# Altium schematic sheet Sheet1.SchDoc
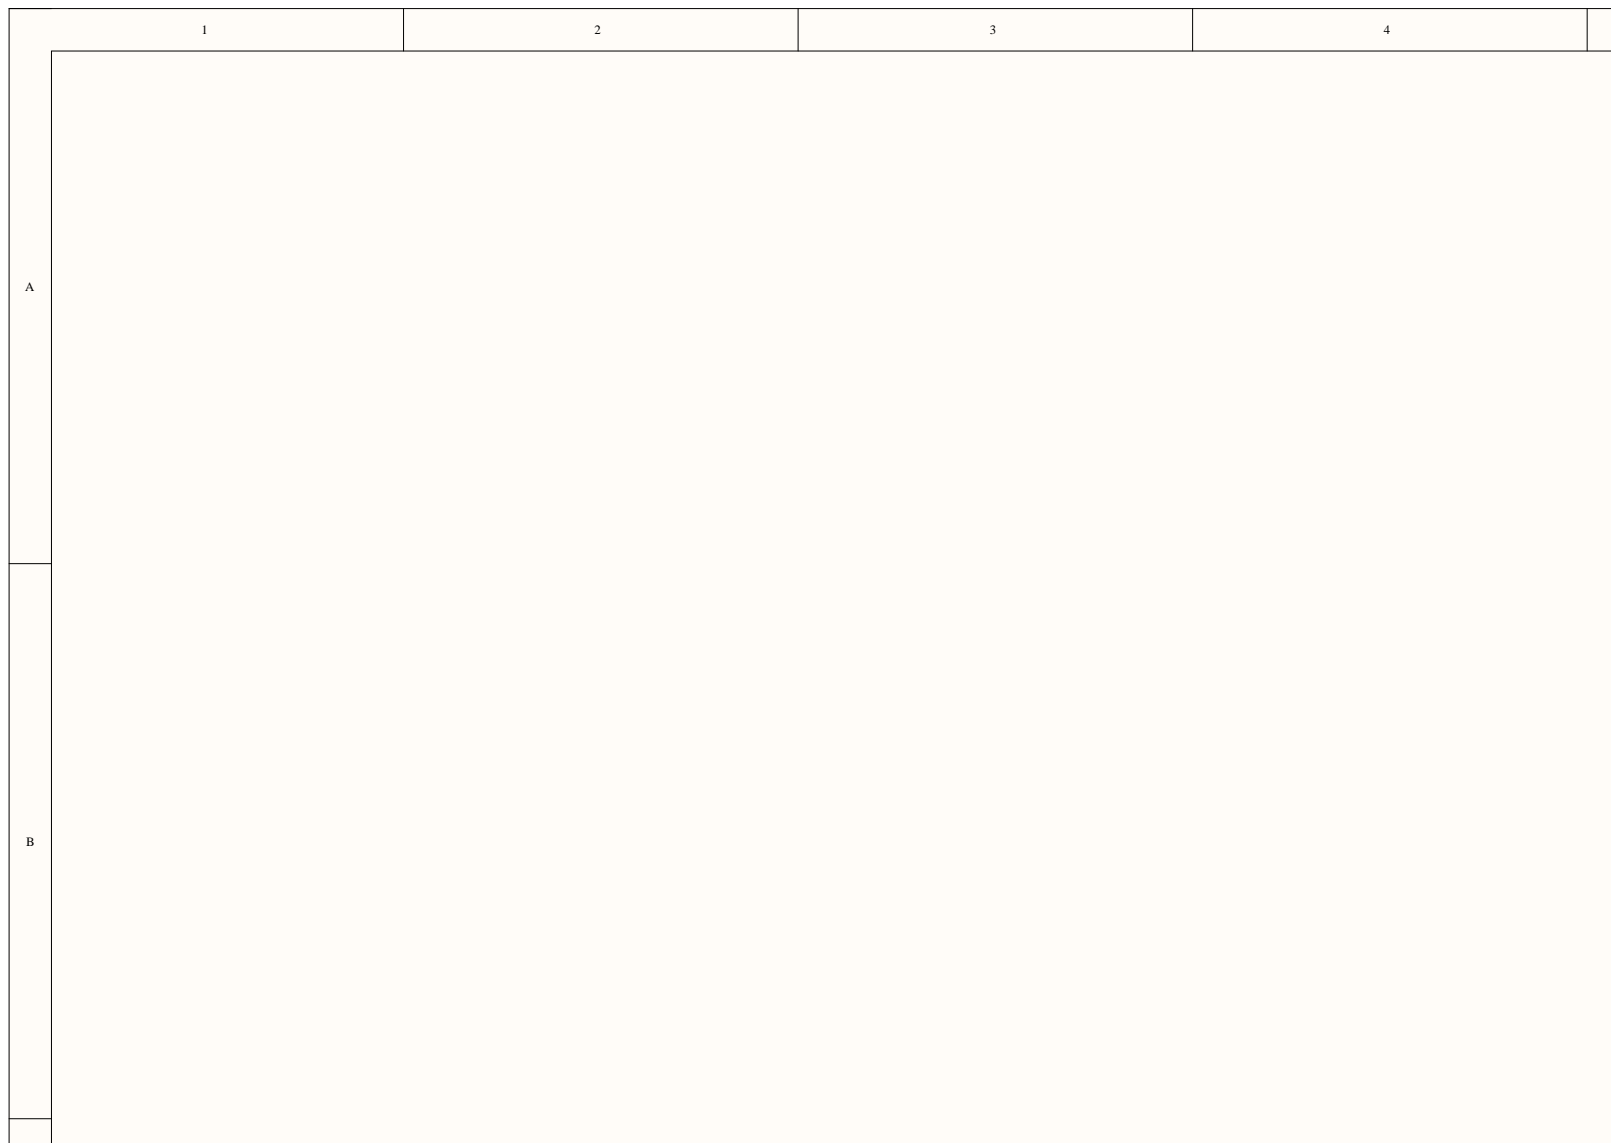
[diagram p0: Sheet1.SchDoc, top left]
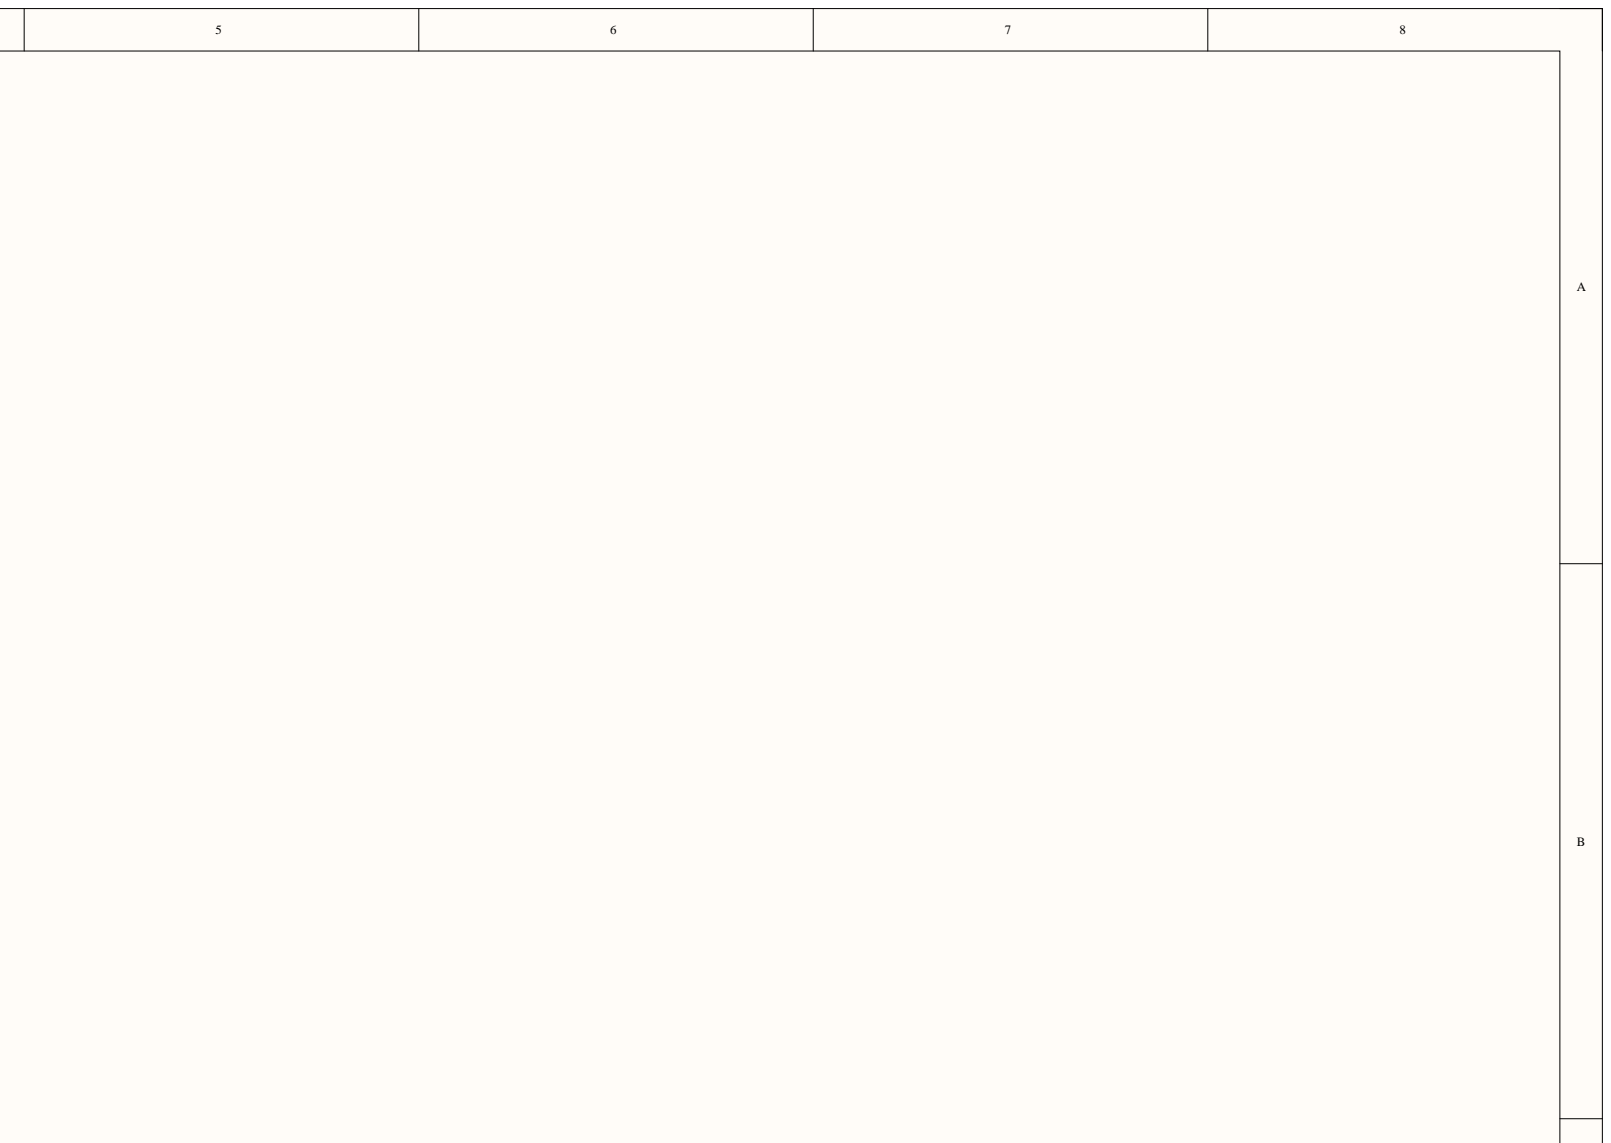
[diagram p1: Sheet1.SchDoc, top right]
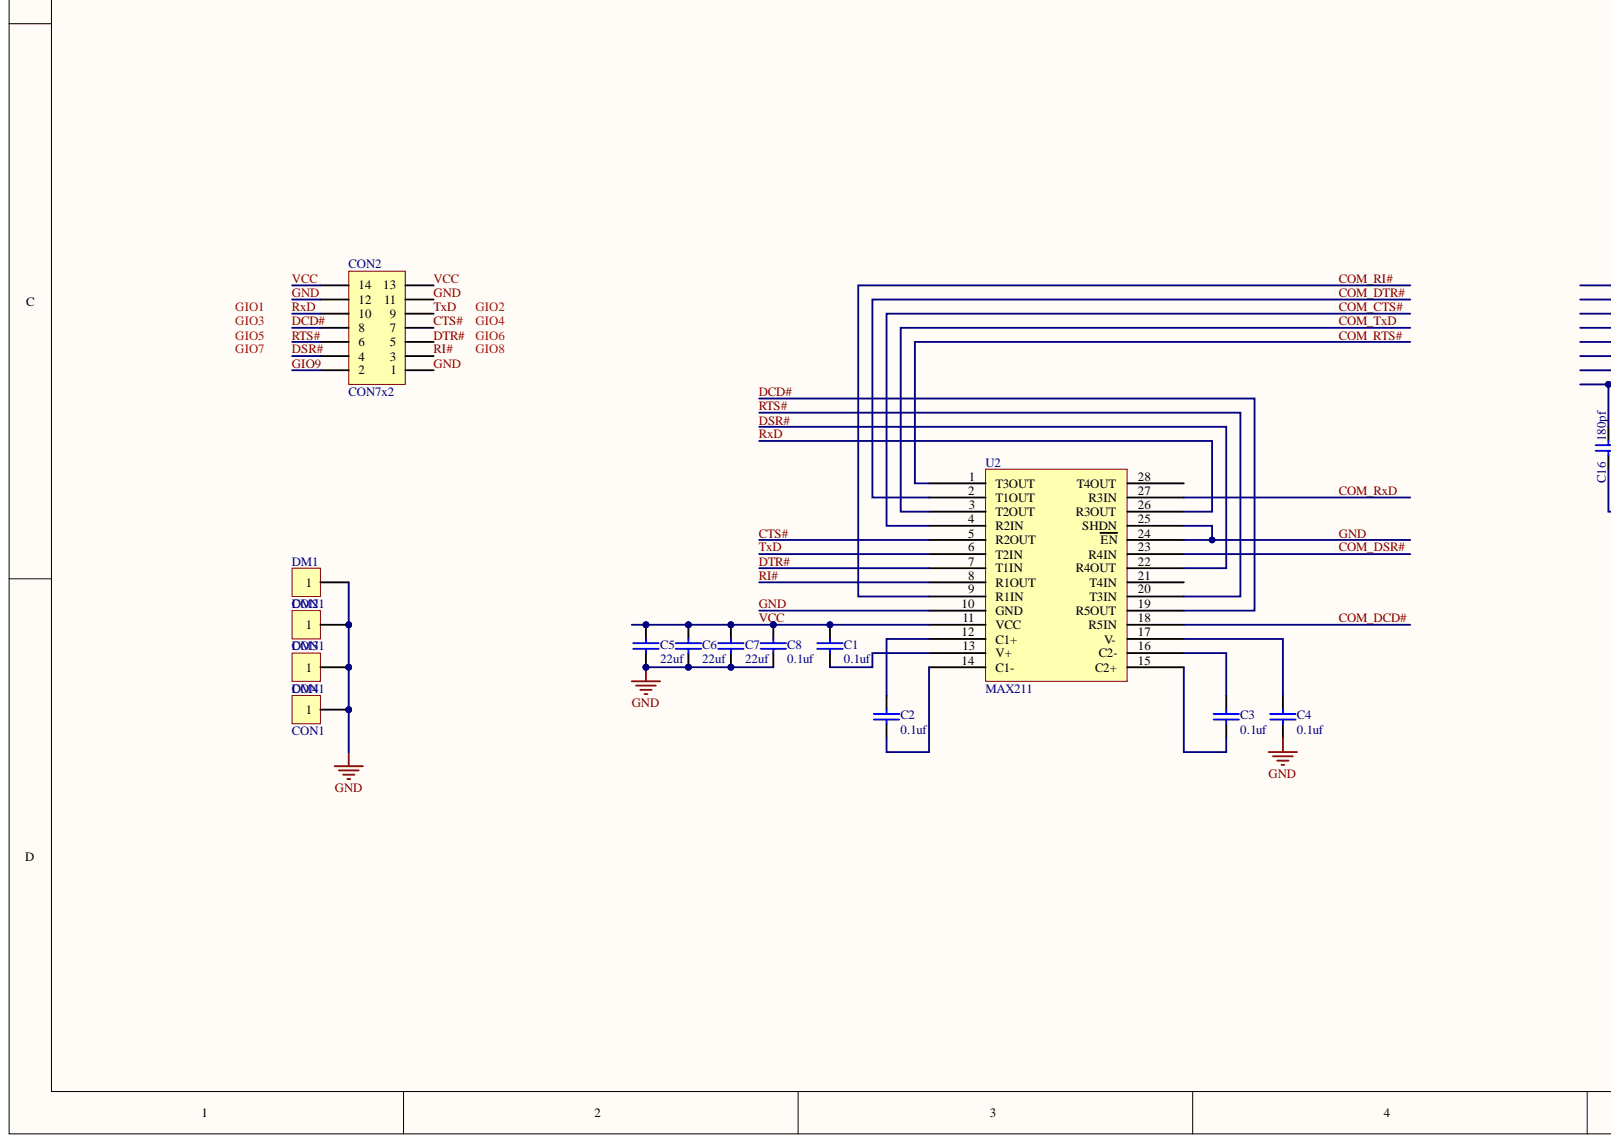
[diagram p2: Sheet1.SchDoc, bottom left]
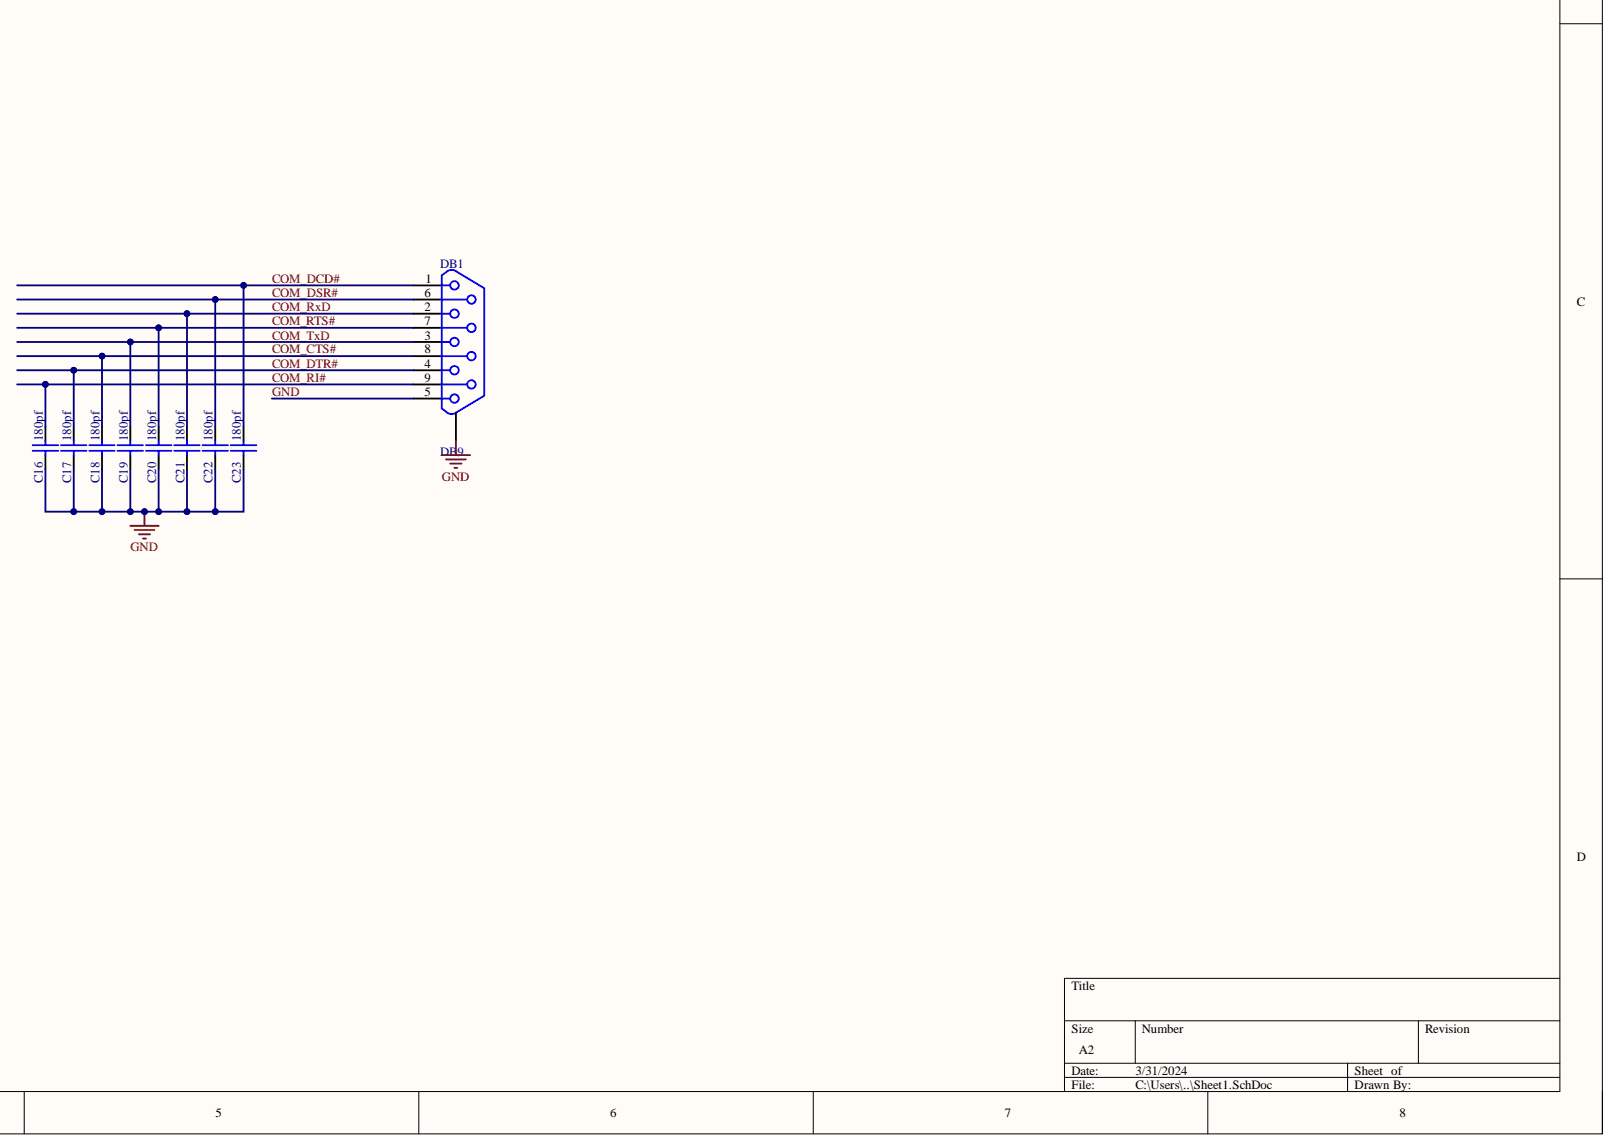
[diagram p3: Sheet1.SchDoc, bottom right]

## Parts
C1  0.1uf  [C0603]
C2  0.1uf  [C0603]
C3  0.1uf  [C0603]
C4  0.1uf  [C0603]
C5  22uf  [C0603]
C6  22uf  [C0603]
C7  22uf  [C0603]
C8  0.1uf  [C0603]
C16  180pf  [C0603]
C17  180pf  [C0603]
C18  180pf  [C0603]
C19  180pf  [C0603]
C20  180pf  [C0603]
C21  180pf  [C0603]
C22  180pf  [C0603]
C23  180pf  [C0603]
CON2  CON7x2  [IDC2x7(2.0)]
DB1  DB9  [DB9公头]
DM1  CON1  [M2.5]
DM2  CON1  [M2.5]
DM3  CON1  [M2.5]
DM4  CON1  [M2.5]
U2  MAX211  [SSOP28]

## Nets
COM_CTS#: C18.1 DB1.8 U2.4(R2IN)
COM_DCD#: C23.1 DB1.1 U2.18(R5IN)
COM_DSR#: C22.1 DB1.6 U2.23(R4IN)
COM_DTR#: C17.1 DB1.4 U2.2(T1OUT)
COM_RI#: C16.1 DB1.9 U2.9(R1IN)
COM_RTS#: C20.1 DB1.7 U2.1(T3OUT)
COM_RxD: C21.1 DB1.2 U2.27(R3IN)
COM_TxD: C19.1 DB1.3 U2.3(T2OUT)
CTS#: CON2.7 U2.5(R2OUT)
DCD#: CON2.8 U2.19(R5OUT)
DSR#: CON2.4 U2.22(R4OUT)
DTR#: CON2.5 U2.7(T1IN)
GIO9: CON2.2
GND: C4.2 C5.2 C6.2 C7.2 C8.2 C16.2 C17.2 C18.2 C19.2 C20.2 C21.2 C22.2 C23.2 CON2.1 CON2.11 CON2.12 DB1.0 DB1.5 DM1.1 DM2.1 DM3.1 DM4.1 U2.10(GND) U2.24(EN) U2.25(SHDN)
RI#: CON2.3 U2.8(R1OUT)
RTS#: CON2.6 U2.20(T3IN)
RxD: CON2.10 U2.26(R3OUT)
TxD: CON2.9 U2.6(T2IN)
VCC: C1.1 C5.1 C6.1 C7.1 C8.1 CON2.13 CON2.14 U2.11(VCC)
NetC1_2: C1.2 U2.13(V+)
NetC2_1: C2.1 U2.12(C1+)
NetC2_2: C2.2 U2.14(C1-)
NetC3_1: C3.1 U2.16(C2-)
NetC3_2: C3.2 U2.15(C2+)
NetC4_1: C4.1 U2.17(V-)
GIO1:
GIO2:
GIO3:
GIO4:
GIO5:
GIO6:
GIO7:
GIO8:

Not connected: U2 21(T4IN) 28(T4OUT)

## Library data of the parts
C1-C8 = 0CAP: Component Kind: Standard; Library Name: 常用元件库.SCHLIB
C16-C23 = CAP: Component Kind: Standard; Library Name: 电阻电容二极管.SCHLIB
CON2 = CON7x2: Component Kind: Standard; Library Name: 常用元件库.SCHLIB
DB1 = DB9: Component Kind: Standard; Library Name: 常用元件库.SCHLIB
DM1-DM4 = CON1: Component Kind: Standard; Library Name: 常用元件库.SCHLIB
U2 = MAX211: Component Kind: Standard; Library Name: 微机原理.SchLib
